# Altium schematic sheet Mounting_PCB_Base.SchDoc
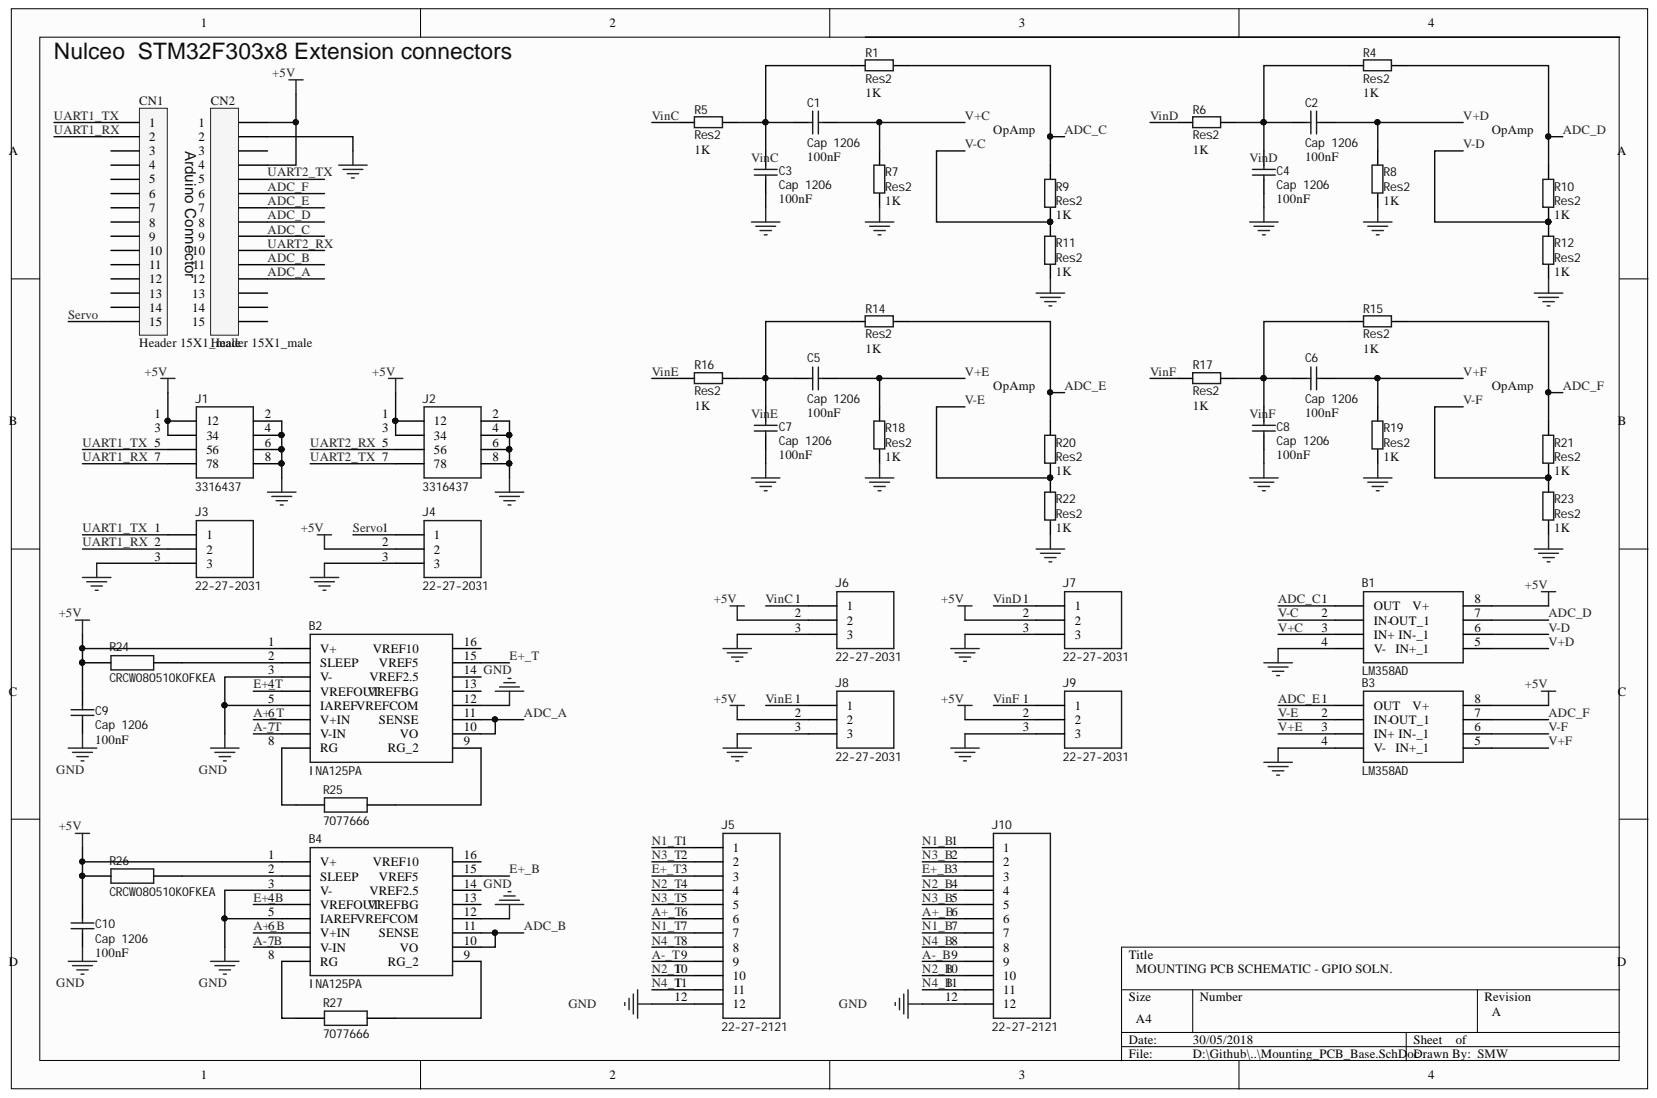
[diagram p0: Mounting_PCB_Base.SchDoc]

## Parts
B1  LM358AD  [SOIC127P600X175-8N]
B2  INA125PA  [DIP254P762X508-16]
B3  LM358AD  [SOIC127P600X175-8N]
B4  INA125PA  [DIP254P762X508-16]
C1  Cap 1206  value 100nF  [C1206]
C2  Cap 1206  value 100nF  [C1206]
C3  Cap 1206  value 100nF  [C1206]
C4  Cap 1206  value 100nF  [C1206]
C5  Cap 1206  value 100nF  [C1206]
C6  Cap 1206  value 100nF  [C1206]
C7  Cap 1206  value 100nF  [C1206]
C8  Cap 1206  value 100nF  [C1206]
C9  Cap 1206  value 100nF  [C1206]
C10  Cap 1206  value 100nF  [C1206]
CN1  Header 15X1_male  [HDR_1x15_2R54_V_F]
CN2  Header 15X1_male  [HDR_1x15_2R54_V_F]
J1  3316437  [RJ45-8]
J2  3316437  [RJ45-8]
J3  22-27-2031  [SHDR3W64P0X254_1X3_762X580X1170P]
J4  22-27-2031  [SHDR3W64P0X254_1X3_762X580X1170P]
J5  22-27-2121  [HDRV12W64P0X254_1X12_3048X580X1170P]
J6  22-27-2031  [SHDR3W64P0X254_1X3_762X580X1170P]
J7  22-27-2031  [SHDR3W64P0X254_1X3_762X580X1170P]
J8  22-27-2031  [SHDR3W64P0X254_1X3_762X580X1170P]
J9  22-27-2031  [SHDR3W64P0X254_1X3_762X580X1170P]
J10  22-27-2121  [HDRV12W64P0X254_1X12_3048X580X1170P]
R1  Res2  value 1K  [C1206]
R4  Res2  value 1K  [C1206]
R5  Res2  value 1K  [C1206]
R6  Res2  value 1K  [C1206]
R7  Res2  value 1K  [C1206]
R8  Res2  value 1K  [C1206]
R9  Res2  value 1K  [C1206]
R10  Res2  value 1K  [C1206]
R11  Res2  value 1K  [C1206]
R12  Res2  value 1K  [C1206]
R14  Res2  value 1K  [C1206]
R15  Res2  value 1K  [C1206]
R16  Res2  value 1K  [C1206]
R17  Res2  value 1K  [C1206]
R18  Res2  value 1K  [C1206]
R19  Res2  value 1K  [C1206]
R20  Res2  value 1K  [C1206]
R21  Res2  value 1K  [C1206]
R22  Res2  value 1K  [C1206]
R23  Res2  value 1K  [C1206]
R24  CRCW080510K0FKEA  [RESC2012X50N]
R25  7077666  [RESAD1550W58L630D230]
R26  CRCW080510K0FKEA  [RESC2012X50N]
R27  7077666  [RESAD1550W58L630D230]

## Nets
+5V: B1.8 B2.1(V+) B3.8 B4.1(V+) C9.2 C10.2 CN2.1 CN2.4 J1.1(1_2) J1.3(3_4) J2.1(1_2) J2.3(3_4) J4.2 J6.2 J7.2 J8.2 J9.2 R24.1 R26.1
GND: B1.4(V-) B2.3(V-) B2.5(IAREF) B2.12 B3.4(V-) B4.3(V-) B4.5(IAREF) B4.12 C3.1 C4.1 C7.1 C8.1 C9.1 C10.1 CN2.2 J1.2 J1.4 J1.6 J1.8 J2.2 J2.4 J2.6 J2.8 J3.3 J4.3 J5.12 J6.3 J7.3 J8.3 J9.3 J10.12 R7.1 R8.1 R11.1 R12.1 R18.1 R19.1 R22.1 R23.1
A+_B: B4.6(V+IN) J10.6
A+_T: B2.6(V+IN) J5.6
A-_B: B4.7(V-IN) J10.9
A-_T: B2.7(V-IN) J5.9
ADC_A: B2.10 B2.11 CN2.12
ADC_B: B4.10 B4.11 CN2.11
ADC_C: B1.1(OUT) CN2.9 R1.2 R9.2
ADC_D: B1.7 CN2.8 R4.2 R10.2
ADC_E: B3.1(OUT) CN2.7 R14.2 R20.2
ADC_F: B3.7 CN2.6 R15.2 R21.2
E+_B: B4.4(VREFOUT) B4.15 J10.3
E+_T: B2.4(VREFOUT) B2.15 J5.3
N1_B: J10.1 J10.7
N1_T: J5.1 J5.7
N2_B: J10.4 J10.10
N2_T: J5.4 J5.10
N3_B: J10.2 J10.5
N3_T: J5.2 J5.5
N4_B: J10.8 J10.11
N4_T: J5.8 J5.11
SERVO: CN1.15 J4.1
UART1_RX: CN1.2 J1.7(7_8) J3.2
UART1_TX: CN1.1 J1.5(5_6) J3.1
UART2_RX: CN2.10 J2.5(5_6)
UART2_TX: CN2.5 J2.7(7_8)
V+C: B1.3 C1.1 R7.2
V+D: B1.5 C2.1 R8.2
V+E: B3.3 C5.1 R18.2
V+F: B3.5 C6.1 R19.2
V-C: B1.2(IN-) R9.1 R11.2
V-D: B1.6 R10.1 R12.2
V-E: B3.2(IN-) R20.1 R22.2
V-F: B3.6 R21.1 R23.2
VINC: J6.1 R5.1
VIND: J7.1 R6.1
VINE: J8.1 R16.1
VINF: J9.1 R17.1
NetB2_2: B2.2(SLEEP) R24.2
NetB2_8: B2.8(RG) R25.1
NetB2_9: B2.9 R25.2
NetB4_2: B4.2(SLEEP) R26.2
NetB4_8: B4.8(RG) R27.1
NetB4_9: B4.9 R27.2
NetC1_2: C1.2 C3.2 R1.1 R5.2
NetC2_2: C2.2 C4.2 R4.1 R6.2
NetC5_2: C5.2 C7.2 R14.1 R16.2
NetC6_2: C6.2 C8.2 R15.1 R17.2

Not connected: B2 13 14 16; B4 13 14 16; CN1 3 4 5 6 7 8 9 10 11 12 13 14; CN2 3 13 14 15

## Library data of the parts
B1 B3 = LM358AD: LM358AD, Dual Operational Amplifier 1.1MHz 5 to 28V, 8-Pin SO; Manufacturer_Name: STMicroelectronics; Geometry.Height: 1.75mm; Component Kind: Standard; Datasheet Link: http://uk.rs-online.com/web/p/products/1657542; Library Name: LM358AD.SchLib; Manufacturer_Part_Number: LM358AD; RS Part Number: 1657542
B2 B4 = INA125PA: Instrumentation Amplifier with Precision Voltage Reference; Manufacturer_Name: Texas Instruments; Component Kind: Standard; Datasheet Link: http://uk.rs-online.com/web/p/products/2521593P; Library Name: INA125PA.SchLib; Manufacturer_Part_Number: INA125PA; RS Part Number: 2521593P
C1-C10 = Cap Semi: Capacitor (Semiconductor SIM Model); Component Kind: Standard; LatestRevisionDate: 29-May-2009; LatestRevisionNote: IPC-7351 Footprint Added.; Library Name: Miscellaneous Devices.IntLib; PackageDescription: Chip Capacitor; PackageReference: C1206; Published: 8-Jun-2000; Signal Integrity: Cap Semi; Simulation: CAP; Publisher: Altium Limited
CN1 CN2 = Header 15X1_Female_1: Header, 10-Pin, Single row,female; Component Kind: Standard; LatestRevisionDate: 17-Jul-2002; LatestRevisionNote: Re-released for DXP Platform.; Library Name: MB1180.SCHLIB; Signal Integrity: Connector; Publisher: Altium Limited
J1 J2 = 3316437: 8/8 way r/a PCB lowprofile data skt,1.5A RS Pro Female Cat5 RJ45 Connector, Unshielded, Right Angle, PCB Mount Mount,; Manufacturer_Name: RS Components; Allied_Number: 70643325; Component Kind: Standard; Datasheet Link: http://uk.rs-online.com/web/p/products/3316437; Library Name: 3316437.SchLib; Manufacturer_Part_Number: 3316437; RS Part Number: 3316437
J3 J4 J6-J9 = 22-27-2031: 3way straight PCB header w/friction lock Molex KK Series, Series Number 6410, 2.54mm Pitch 3 Way 1 Row Straight PCB Header, Solder Termination, 4A; Allied_Number: 70190935; Geometry.Height: 11.7mm; Component Kind: Standard; Datasheet Link: http://uk.rs-online.com/web/p/products/1707102; Library Name: 22-27-2031.SchLib; Manufacturer_Name: Molex; Manufacturer_Part_Number: 22-27-2031; RS Part Number: 1707102
J5 J10 = 22-27-2121: 12way straightPCB header w/friction lock Molex KK Series, Series Number 6410, 2.54mm Pitch 12 Way 1 Row Straight PCB Header, Solder Termination, 4A; Allied_Number: 70373056; Geometry.Height: 11.7mm; Component Kind: Standard; Datasheet Link: http://uk.rs-online.com/web/p/products/1707108; Library Name: 22-27-2121.SchLib; Manufacturer_Name: Molex; Manufacturer_Part_Number: 22-27-2121; RS Part Number: 1707108
R1 R4-R12 R14-R23 = Res2: Resistor; Component Kind: Standard; LatestRevisionDate: 17-Jul-2002; LatestRevisionNote: Re-released for DXP Platform.; Library Name: Miscellaneous Devices.IntLib; PackageDescription: Axial Device, Thru-Hole; 2 Leads; 0.4 in Pin Spacing; PackageReference: AXIAL-0.4; Published: 8-Jun-2000; Signal Integrity: Res; Simulation: RESISTOR; Publisher: Altium Limited
R24 R26 = CRCW080510K0FKEA: CRCW0805 Resistor T/R 0.125W,1%,10K Vishay CRCW Series Thick Film Surface Mount Resistor 0805 Case 10k 1% 0.125W 100ppm/C; Allied_Number: 70203987; Geometry.Height: 0.5mm; Component Kind: Standard; Datasheet Link: http://uk.rs-online.com/web/p/products/1694499; Library Name: CRCW080510K0FKEA.SchLib; Manufacturer_Name: Vishay; Manufacturer_Part_Number: CRCW080510K0FKEA; RS Part Number: 1694499
R25 R27 = 7077666: RS Pro RS Series Axial Carbon Resistor 1k 5% 0.25W -500  +350ppm/C; Manufacturer_Name: RS Components; Component Kind: Standard; Datasheet Link: https://componentsearchengine.com/Datasheets/3/7077666.pdf; Library Name: 7077666.SchLib; Manufacturer_Part_Number: 7077666; RS Part Number: 7077666
